# Altium schematic sheet SH3_BI-Gr_Potentiostat_MPUcore_R0.05.00.SchDoc(SH3_BI-Gr_Potentiostat_MPUcore_R0.05.00)
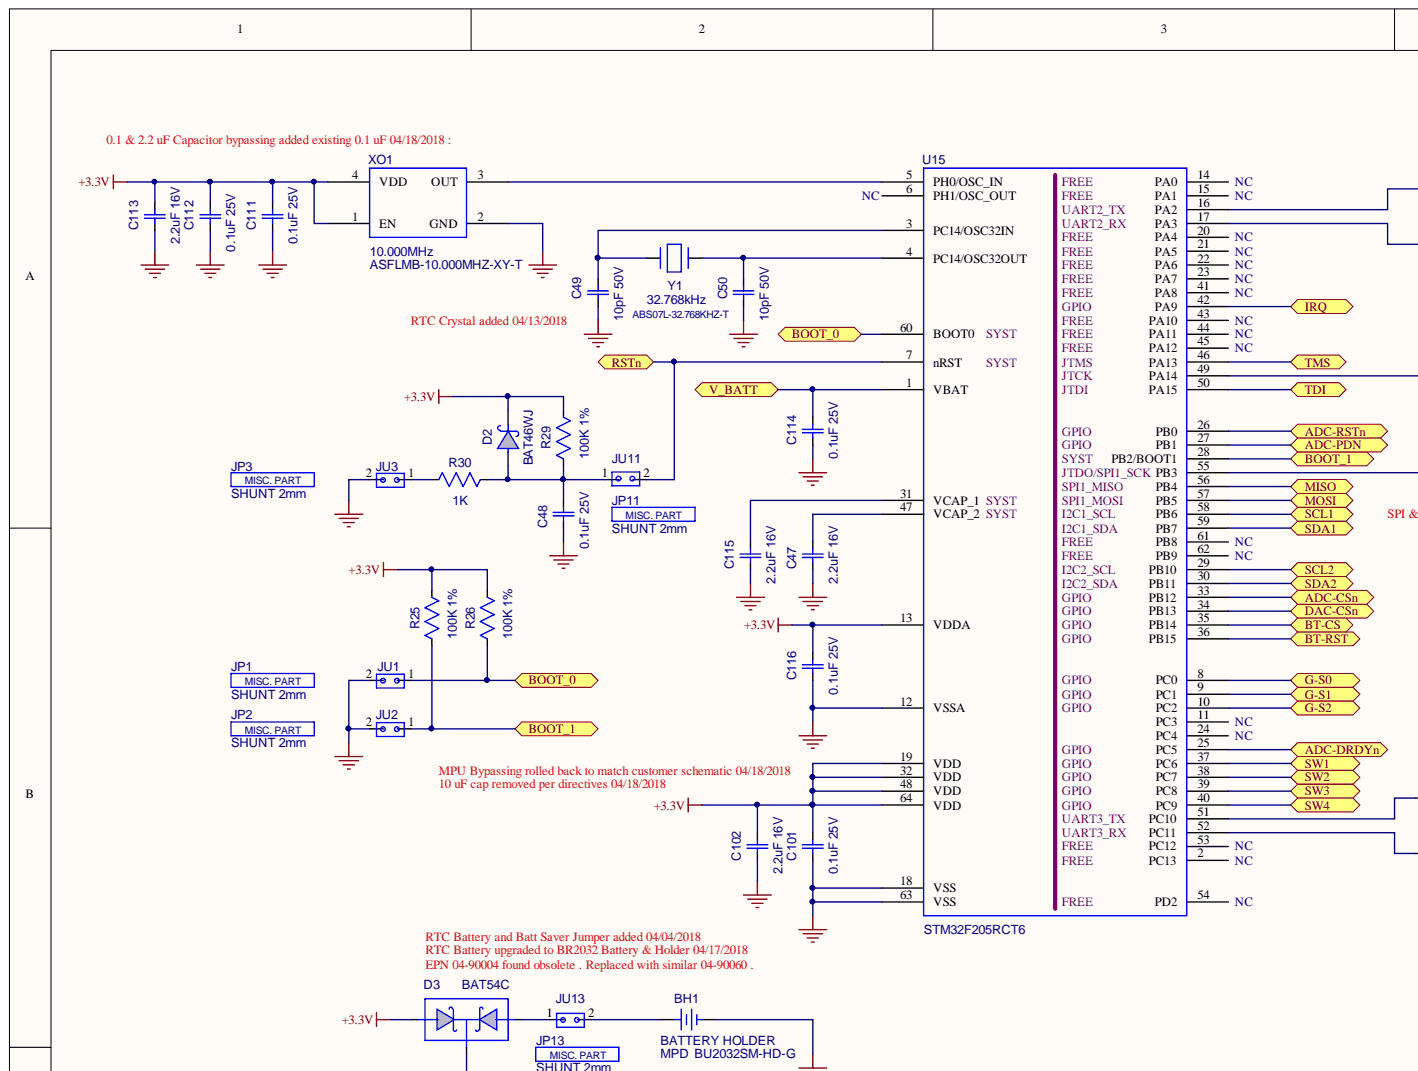
[diagram p0: SH3_BI-Gr_Potentiostat_MPUcore_R0.05.00.SchDoc, top left]
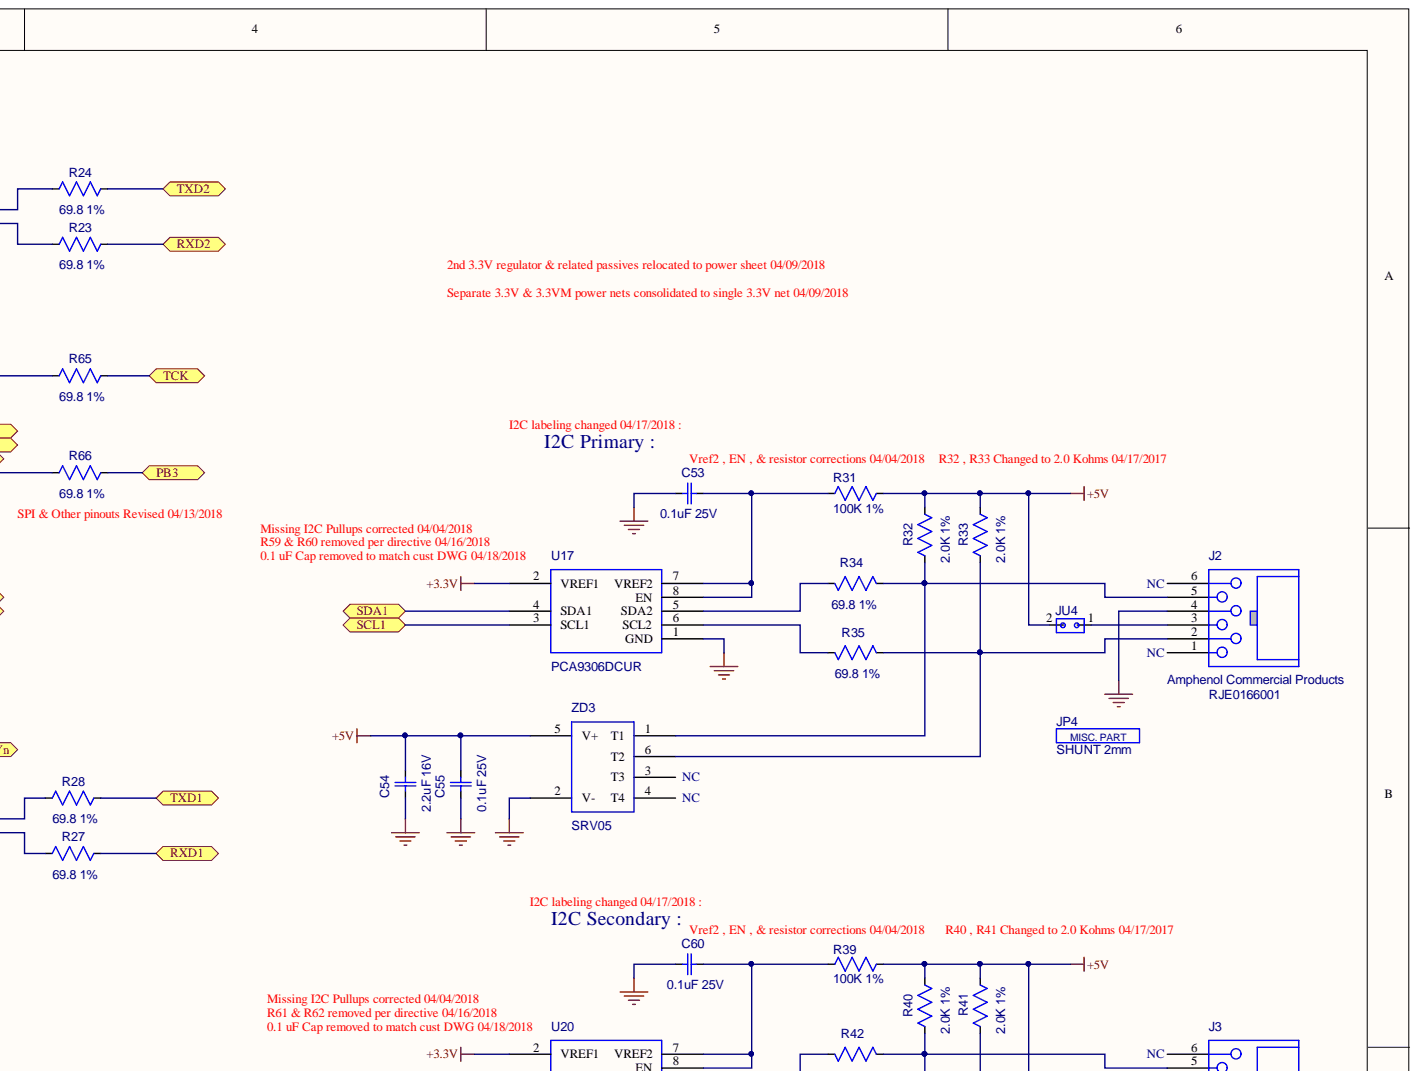
[diagram p1: SH3_BI-Gr_Potentiostat_MPUcore_R0.05.00.SchDoc, top right]
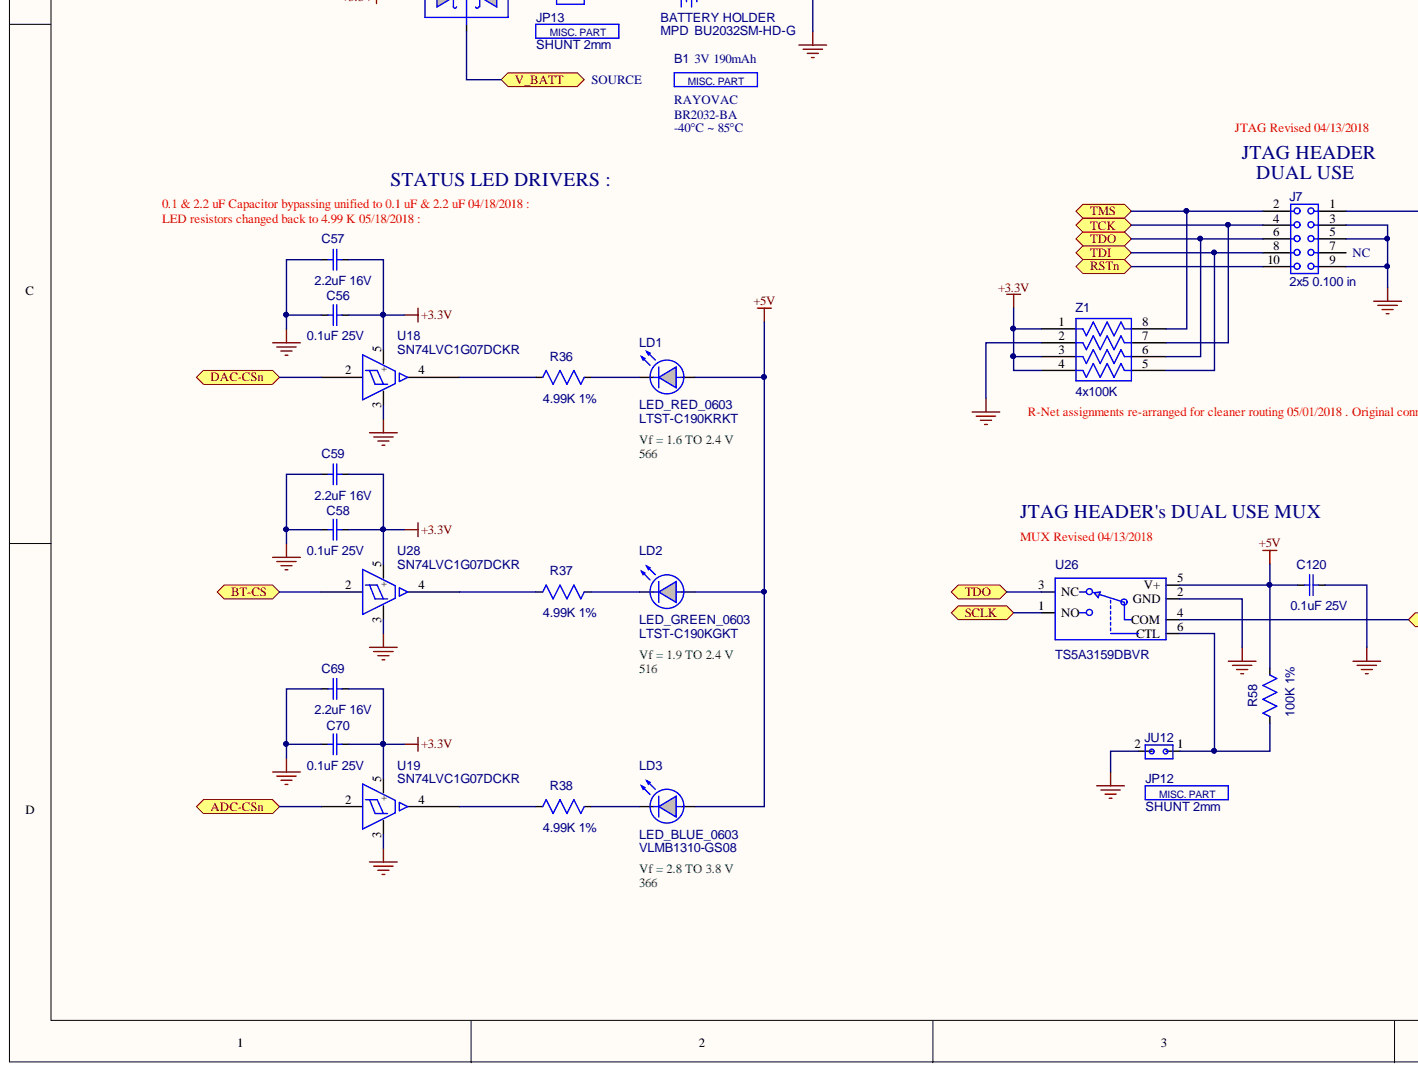
[diagram p2: SH3_BI-Gr_Potentiostat_MPUcore_R0.05.00.SchDoc, bottom left]
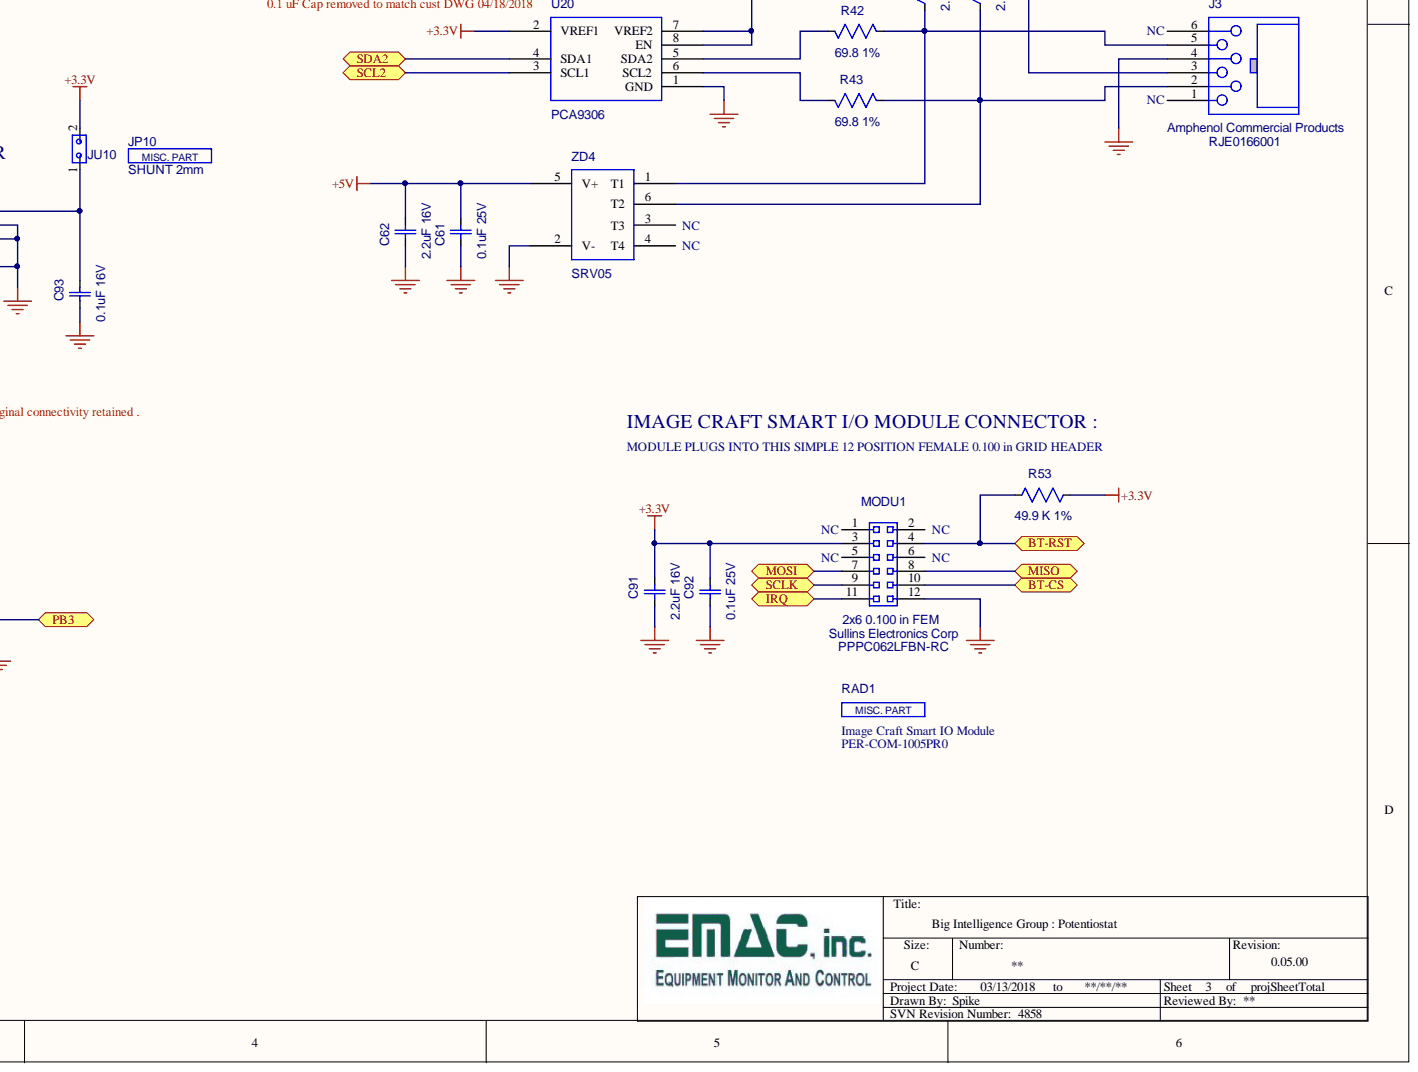
[diagram p3: SH3_BI-Gr_Potentiostat_MPUcore_R0.05.00.SchDoc, bottom right]

## Parts
B1  BR2032  value 3V 190mAh  Temp Range (°C): -40°C ~ 85°C  Notes: 190mAh  Manufacturer PN: BR2032-BA  Manufacturer: RAYOVAC  [PHANTOM_BATT_20MM_COIN_PAT]
BH1  BATTERY HOLDER  Manufacturer PN: BU2032SM-HD-G  Manufacturer: MPD  [BATT_HLDR_BU2032_SM_PAT]
C47  2.2uF 16V  value 2.2uF  Notes: 16V  [CC0603_IPC_L]
C48  0.1uF 25V  Notes: 25V  [CC0603_IPC_L]
C49  10pF 50V  value 10pF  Notes: 50V  [CC0603_IPC_L]
C50  10pF 50V  value 10pF  Notes: 50V  [CC0603_IPC_L]
C53  0.1uF 25V  Notes: 25V  [CC0603_IPC_L]
C54  2.2uF 16V  value 2.2uF  Notes: 16V  [CC0603_IPC_L]
C55  0.1uF 25V  Notes: 25V  [CC0603_IPC_L]
C56  0.1uF 25V  Notes: 25V  [CC0603_IPC_L]
C57  2.2uF 16V  value 2.2uF  Notes: 16V  [CC0603_IPC_L]
C58  0.1uF 25V  Notes: 25V  [CC0603_IPC_L]
C59  2.2uF 16V  value 2.2uF  Notes: 16V  [CC0603_IPC_L]
C60  0.1uF 25V  Notes: 25V  [CC0603_IPC_L]
C61  0.1uF 25V  Notes: 25V  [CC0603_IPC_L]
C62  2.2uF 16V  value 2.2uF  Notes: 16V  [CC0603_IPC_L]
C69  2.2uF 16V  value 2.2uF  Notes: 16V  [CC0603_IPC_L]
C70  0.1uF 25V  Notes: 25V  [CC0603_IPC_L]
C91  2.2uF 16V  value 2.2uF  Notes: 16V  [CC0603_IPC_L]
C92  0.1uF 25V  Notes: 25V  [CC0603_IPC_L]
C93  0.1uF 16V  value 0.1uF  Notes: 16V  [CC0603_IPC_L]
C101  0.1uF 25V  Notes: 25V  [CC0603_IPC_L]
C102  2.2uF 16V  value 2.2uF  Notes: 16V  [CC0603_IPC_L]
C111  0.1uF 25V  Notes: 25V  [CC0603_IPC_L]
C112  0.1uF 25V  Notes: 25V  [CC0603_IPC_L]
C113  2.2uF 16V  value 2.2uF  [CC0603_IPC_L]
C114  0.1uF 25V  Notes: 25V  [CC0603_IPC_L]
C115  2.2uF 16V  value 2.2uF  Notes: 16V  [CC0603_IPC_L]
C116  0.1uF 25V  Notes: 25V  [CC0603_IPC_L]
C120  0.1uF 25V  Notes: 25V  [CC0603_IPC_L]
D2  BAT46WJ  [DIO_BAT46WJ_SOD323]
D3  BAT54C  [SOT23_3_BAT54C]
J2  RJE0166001  Manufacturer: Amphenol Commercial Products  [MOCON_RJE0166001_PAT]
J3  RJE0166001  Manufacturer: Amphenol Commercial Products  [MOCON_RJE0166001_PAT]
J7  Notes: 2x5 0.100 in  [CHDR10_PAT2]  (hidden comment: CHDR10)
JP1  SHUNT 2mm  [SHUNT_2MM_PAT]
JP2  SHUNT 2mm  [SHUNT_2MM_PAT]
JP3  SHUNT 2mm  [SHUNT_2MM_PAT]
JP4  SHUNT 2mm  [SHUNT_2MM_PAT]
JP10  SHUNT 2mm  [SHUNT_2MM_PAT]
JP11  SHUNT 2mm  [SHUNT_2MM_PAT]
JP12  SHUNT 2mm  [SHUNT_2MM_PAT]
JP13  SHUNT 2mm  [SHUNT_2MM_PAT]
JU1  1x2_2mm  [2MMSHDR_02_PAT2]  (value not drawn)
JU2  1x2_2mm  [2MMSHDR_02_PAT2]  (value not drawn)
JU3  1x2_2mm  [2MMSHDR_02_PAT2]  (value not drawn)
JU4  1x2_2mm  [2MMSHDR_02_PAT2]  (value not drawn)
JU10  1x2_2mm  [2MMSHDR_02_PAT2]  (value not drawn)
JU11  1x2_2mm  [2MMSHDR_02_PAT2]  (value not drawn)
JU12  1x2_2mm  [2MMSHDR_02_PAT2]  (value not drawn)
JU13  1x2_2mm  [2MMSHDR_02_PAT2]  (value not drawn)
LD1  LED_RED_0603  Manufacturer PN: LTST-C190KRKT  [LED_0603_RED_PAT]
LD2  LED_GREEN_0603  Manufacturer PN: LTST-C190KGKT  [LED_0603_GRN_PAT]
LD3  LED_BLUE_0603  Manufacturer PN: VLMB1310-GS08  [LED_0603_BLUE_PAT]
MODU1  Notes: 2x6 0.100 in FEM  Manufacturer PN: PPPC062LFBN-RC  Manufacturer: Sullins Electronics Corp  [CHDR12_FEM_PAT]
R23  69.8 1%  [RC0603_IPC_L]
R24  69.8 1%  [RC0603_IPC_L]
R25  100K 1%  [RC0603_IPC_L]
R26  100K 1%  [RC0603_IPC_L]
R27  69.8 1%  [RC0603_IPC_L]
R28  69.8 1%  [RC0603_IPC_L]
R29  100K 1%  [RC0603_IPC_L]
R30  1K  [RC0603_IPC_L]
R31  100K 1%  [RC0603_IPC_L]
R32  2.0K 1%  [RC0603_IPC_L]
R33  2.0K 1%  [RC0603_IPC_L]
R34  69.8 1%  [RC0603_IPC_L]
R35  69.8 1%  [RC0603_IPC_L]
R36  4.99K 1%  [RC0603_IPC_L]
R37  4.99K 1%  [RC0603_IPC_L]
R38  4.99K 1%  [RC0603_IPC_L]
R39  100K 1%  [RC0603_IPC_L]
R40  2.0K 1%  [RC0603_IPC_L]
R41  2.0K 1%  [RC0603_IPC_L]
R42  69.8 1%  [RC0603_IPC_L]
R43  69.8 1%  [RC0603_IPC_L]
R53  49.9 K 1%  [RC0603_IPC_L]
R58  100K 1%  [RC0603_IPC_L]
R65  69.8 1%  [RC0603_IPC_L]
R66  69.8 1%  [RC0603_IPC_L]
RAD1  PER-COM-1005PR0  Notes: Image Craft Smart IO Module  [MOD_SMART_IO_BT]
U15  STM32F205RCT6  [QFP50P1200X1200X160-64N]
U17  9306  Manufacturer PN: PCA9306DCUR  [VSSOP_8_PAT]
U18  SN74LVC1G07DCKR  [SC70-5_PAT]
U19  SN74LVC1G07DCKR  [SC70-5_PAT]
U20  PCA9306  [VSSOP_8_PAT]
U26  TS5A3159DBVR  [RPDSOG_06_SPK]
U28  SN74LVC1G07DCKR  [SC70-5_PAT]
XO1  10.000MHz  Manufacturer PN: ASFLMB-10.000MHZ-XY-T  [XTALOSC_5P0X3P2MM_ASFLMB_PAT]
Y1  32.768kHz  [XTL_ABS07L_PAT]
Z1  4x100K  [RNET_CAT10-0804_X4_PAT]
ZD3  SRV05  [SRV05-4_PAT]
ZD4  SRV05  [SRV05-4_PAT]

## Ports
ADC-CSn, ADC-DRDYn, ADC-PDN, ADC-RSTn, BOOT_0, BOOT_1, BT-CS, BT-RST, DAC-CSn, G-S0, G-S1, G-S2, IRQ, MISO, MOSI, PB3, RSTn, RXD1, RXD2, SCL1, SCL2, SCLK, SDA1, SDA2, SW1, SW2, SW3, SW4, TCK, TDI, TDO, TMS, TXD1, TXD2, V_BATT

## Nets
+3.3V: C56.1 C57.1 C58.1 C59.1 C69.1 C70.1 C91.1 C92.1 C101.1 C102.1 C111.1 C112.1 C113.1 C116.1 D2.1 D3.1 JU10.2 MODU1.3 R25.2 R26.2 R29.2 R53.2 U15.13(VDDA) U15.19(VDD) U15.32(VDD) U15.48(VDD) U15.64(VDD) U17.2(VREF1) U18.5(+) U19.5(+) U20.2(VREF1) U28.5(+) XO1.1(EN) XO1.4(VDD) Z1.1 Z1.3 Z1.4
+5V: C54.1 C55.1 C61.1 C62.1 C120.1 J3.3 JU4.2 LD1.2 LD2.2 LD3.2 R31.2 R32.2 R33.2 R39.2 R40.2 R41.2 R58.2 U26.5(V+) ZD3.5(V+) ZD4.5(V+)
GND: BH1.2 C47.2 C48.2 C49.2 C50.2 C53.2 C54.2 C55.2 C56.2 C57.2 C58.2 C59.2 C60.2 C61.2 C62.2 C69.2 C70.2 C91.2 C92.2 C93.2 C101.2 C102.2 C111.2 C112.2 C113.2 C114.2 C115.2 C116.2 C120.2 J2.4 J3.4 J7.3 J7.5 J7.9 JU1.2 JU2.2 JU3.2 JU12.2 MODU1.12 U15.12(VSSA) U15.18(VSS) U15.63(VSS) U17.1(GND) U18.3 U19.3 U20.1(GND) U26.2(GND) U28.3 XO1.2(GND) Z1.2 ZD3.2(V-) ZD4.2(V-)
ADC-CSn: U15.33(PB12) U19.2 ; port ADC-CSn
ADC-DRDYn: U15.25(PC5) ; port ADC-DRDYn
ADC-PDN: U15.27(PB1) ; port ADC-PDN
ADC-RSTn: U15.26(PB0) ; port ADC-RSTn
BOOT_0: JU1.1 R26.1 U15.60(BOOT0) ; port BOOT_0
BOOT_1: JU2.1 R25.1 U15.28(PB2/BOOT1) ; port BOOT_1
BT-CS: MODU1.10 U15.35(PB14) U28.2 ; port BT-CS
BT-RST: MODU1.4 R53.1 U15.36(PB15) ; port BT-RST
DAC-CSn: U15.34(PB13) U18.2 ; port DAC-CSn
G-S0: U15.8(PC0) ; port G-S0
G-S1: U15.9(PC1) ; port G-S1
G-S2: U15.10(PC2) ; port G-S2
IRQ: MODU1.11 U15.42(PA9) ; port IRQ
MISO: MODU1.8 U15.56(PB4) ; port MISO
MOSI: MODU1.7 U15.57(PB5) ; port MOSI
PB3: R66.2 U26.4(COM) ; port PB3
RSTn: J7.10 JU11.2 U15.7(nRST) ; port RSTn
RXD1: R27.2 ; port RXD1
RXD2: R23.2 ; port RXD2
SCL1: U15.58(PB6) U17.3(SCL1) ; port SCL1
SCL2: U15.29(PB10) U20.3(SCL1) ; port SCL2
SCLK: MODU1.9 U26.1(NO) ; port SCLK
SDA1: U15.59(PB7) U17.4(SDA1) ; port SDA1
SDA2: U15.30(PB11) U20.4(SDA1) ; port SDA2
SW1: U15.37(PC6) ; port SW1
SW2: U15.38(PC7) ; port SW2
SW3: U15.39(PC8) ; port SW3
SW4: U15.40(PC9) ; port SW4
TCK: J7.4 R65.2 Z1.7 ; port TCK
TDI: J7.8 U15.50(PA15) Z1.5 ; port TDI
TDO: J7.6 U26.3(NC) Z1.6 ; port TDO
TMS: J7.2 U15.46(PA13) Z1.8 ; port TMS
TXD1: R28.2 ; port TXD1
TXD2: R24.2 ; port TXD2
V_BATT: C114.1 D3.3 U15.1(VBAT) ; port V_BATT
NetBH1_1: BH1.1 JU13.2
NetC47_1: C47.1 U15.47(VCAP_2)
NetC48_1: C48.1 D2.2 JU11.1 R29.1 R30.2
NetC49_1: C49.1 U15.3(PC14/OSC32IN) Y1.1
NetC50_1: C50.1 U15.4(PC14/OSC32OUT) Y1.3
NetC53_1: C53.1 R31.1 U17.7(VREF2) U17.8(EN)
NetC60_1: C60.1 R39.1 U20.7(VREF2) U20.8(EN)
NetC93_1: C93.1 J7.1 JU10.1
NetC115_1: C115.1 U15.31(VCAP_1)
NetD3_2: D3.2 JU13.1
NetJ2_2: J2.2 R33.1 R35.1 ZD3.6(T2)
NetJ2_3: J2.3 JU4.1
NetJ2_5: J2.5 R32.1 R34.1 ZD3.1(T1)
NetJ3_2: J3.2 R41.1 R43.1 ZD4.6(T2)
NetJ3_5: J3.5 R40.1 R42.1 ZD4.1(T1)
NetJU3_1: JU3.1 R30.1
NetJU12_1: JU12.1 R58.1 U26.6(CTL)
NetLD1_1: LD1.1 R36.1
NetLD2_1: LD2.1 R37.1
NetLD3_1: LD3.1 R38.1
NetR23_1: R23.1 U15.17(PA3)
NetR24_1: R24.1 U15.16(PA2)
NetR27_1: R27.1 U15.52(PC11)
NetR28_1: R28.1 U15.51(PC10)
NetR34_2: R34.2 U17.5(SDA2)
NetR35_2: R35.2 U17.6(SCL2)
NetR36_2: R36.2 U18.4
NetR37_2: R37.2 U28.4
NetR38_2: R38.2 U19.4
NetR42_2: R42.2 U20.5(SDA2)
NetR43_2: R43.2 U20.6(SCL2)
NetR65_1: R65.1 U15.49(PA14)
NetR66_1: R66.1 U15.55(JTDO/SPI1_SCK_PB3)
NetU15_5: U15.5(PH0/OSC_IN) XO1.3(OUT)

Not connected: J2 1 6; J3 1 6; J7 7; MODU1 1 2 5 6; U15 2(PC13) 6(PH1/OSC_OUT) 11(PC3) 14(PA0) 15(PA1) 20(PA4) 21(PA5) 22(PA6) 23(PA7) 24(PC4) 41(PA8) 43(PA10) 44(PA11) 45(PA12) 53(PC12) 54(PD2) 61(PB8) 62(PB9); ZD3 3(T3) 4(T4); ZD4 3(T3) 4(T4)

## Library data of the parts
B1 = 16-90017: BATT^LITHM 3V 190mA 20MM COIN; SVN Revision No: 2917; Status Code: L; Reviewed By: JP; Options: 00; Database Table Name: misc; Type: M; RoHS: YES; Part Number: 16-90017; Package: N/A; MSL: 1; Library Name: MySQL_ALTIUM.DbLib; Height Max: 3.20 mm; Date Updated: 7/12/2016; Datasheet: http://na.industrial.panasonic.com/sites/default/pidsa/files/brseries_datasheets_merged.pdf; Component Kind: Standard; Author: ML
BH1 = 02-90192: NBH^LCN 20mmCR2032 Horiz   SMD; Part Number: {Part Number}; Value: {Value}; Temp Range (C): -40°C ~ 280°C; svn_revision_no: 558; Status Code: L; Options: 00; Notes: {Notes}; ManfacturerPN: {ManfacturerPN}; Information: {Information}; id: 293; Database Table Name: connectors; Type: S; RoHS: YES; Part Number: 02-90192; Library Name: MySQL_ALTIUM.DbLib; Datasheet: http://www.memoryprotectiondevices.com/datasheets/BU2032SM-HD-G-datasheet.pdf; Component Kind: Standard; ComponentHeight: {ComponentHeight}; Verification Date: {Verification Date}; Author: Mike L.
C47 C54 C57 C59 C62 C69 C91 C102 C113 C115 = 09-90070: CCG^  2.2uF  16V 0603  10% X5R; type: S; SVN Revision No.: 5061; Status Code: L; Options: 00; Database Table Name: passives; Part Number: 09-90070; Package: 0603; msl: 1; Library Name: MySQL_ALTIUM.DbLib; Height Max (mm): 0.90 mm; Component Kind: Standard; Author: Mike L.
  C113: Value: 2.2uF; Notes: 16V
C48 C53 C55 C56 C58 C60 C61 C70 C92 C101 C111 C112 C114 C116 C120 = 09-90081: CCG^0.1uF   25V 0603   10% X7R; Manufacturer PN: C0603C104K3RAC7411; Manufacturer: Kemet; Value: .1 uF; type: S; Temp Range (°C): -55°C ~ 125°C; SVN Revision No.: 5061; Status Code: L; Options: 00; Database Table Name: passives; RoHS: YES; Part Number: 09-90081; Package: 0603; msl: 1; Library Name: MySQL_ALTIUM.DbLib; Height Max (mm): 0.90 mm; Component Kind: Standard; Author: Mike L.
C49 C50 = 09-90019: CCG^10pF   50V 0603    5% C0G; Type: S; SVN Revision No.: 5061; Status Code: L; Options: 00; Database Table Name: passives; Part Number: 09-90019; Package: 0603; MSL: 1; Library Name: MySQL_ALTIUM.DbLib; Height Max (mm): 0.87 mm; Component Kind: Standard; Author: Mike L.
C93 = 09-90002: CCG^0.1uF   16V 0603   10% X7R; Manufacturer PN: C0603C104K4RAC7411; Manufacturer: Kemet; Type: S; Temp Range (°C): -55°C ~ 125°C; SVN Revision No.: 5061; Status Code: L; Options: 00; Database Table Name: passives; RoHS: YES; Part Number: 09-90002; Package: 0603; MSL: 1; Library Name: MySQL_ALTIUM.DbLib; Height Max (mm): 0.87 mm; Datasheet: http://www.kemet.com/datasheets&C0603C104K4RAC7411; Component Kind: Standard; Author: Mike L.
D2 = 04-90048: DSB^100V 0.25A BAT46WJ  SOD-32; Manufacturer PN: BAT46WJ,115; Manufacturer: NXP Semiconductors; Type: S; Temp Range (°C): -55°C ~ 150°C; Status Code: P; Options: 00; Notes: Vf=850mV @ 250mA, Vr=100V; Database Table Name: actives; ROHS: YES; Part Number: 04-90048; Package: SOD-323; MSL: 1; Library Name: MySQL_ALTIUM.DbLib; Height Max (mm): 0.80 mm; Datasheet: http://www.nxp.com/documents/data_sheet/BAT46WJ.pdf; Component Kind: Standard; Author: TM
D3 = 04-90005: Diode^Dual Schottkey BAT54C; Part Number: 04-90060; Value: 0.2A 30V; Type: S; Temp Range (°C): -55,  +125; SVN Revision No.: 3604; Status Code: L; Options: 00; Notes: Vbr=30V, If=200mA, Trr=5nS; Database Table Name: actives; ROHS: Yes; Package: SOT23-3; MSL: 1; Manufacturer PN: BAT54C; Library Name: MySQL_ALTIUM.DbLib; Last Updated: 2/14/17; Height Max (mm): 1.20 mm; Datasheet: http://www.diodes.com/datasheets/bat54srs.pdf; Component Kind: Standard; Author: Mike L.
J2 J3 = 02-90498: NMI^JHE RJ-11 6pos6cont Unshld; Temp Range (°C): -55°C ~ 85°C; SVN Revision No.: 4643; Status Code: N; Options: 00; Database Table Name: connectors; Type: M; RoHS: YES; Part Number: 02-90498; MSL: 1; Manufacturer PN: RJE0166001; Library Name: MySQL_ALTIUM.DbLib; Last Updated: 3/20/18; Height Max (mm): 12.88 mm; Datasheet: https://www.amphenolcanada.com/ProductSearch/pdf/RJE01_BRO.pdf; Component Kind: Standard; Author: JP
J7 = 20-0310: NHD^MPE 2X5 0.1" GOLD PRE; Manufacturer: EMAC inc.; Temp Range (°C): {Temp Range}; SVN Revision No.: 1967; Status Code: L; Options: 00; Information: {Information}; Database Table Name: connectors; Type: M; RoHS: YES; Part Number: 20-0310; MSL: N/A; Library Name: MySQL_ALTIUM.DbLib; Height Max (mm): 8.50 mm; Component Kind: Standard; Author: ML
JP1-JP4 JP10-JP13 = 02-0701: SHUNT^HDR MICRO 2MM; Manufacturer PN: 86730-101LF; Manufacturer: FCI; Temp Range (°C): {Temp Range}; SVN Revision No.: 2917; Status Code: L; Options: 00; Notes: {Notes}; Information: {Information}; Database Table Name: connectors; Type: M; RoHS: YES; Part Number: 02-0701; MSL: 1; Library Name: MySQL_ALTIUM.DbLib; Height Max (mm): 3.50 mm; Component Kind: Standard; Author: Mike L.
JU1-JU4 JU10-JU13 = 20-1502: NHD^MPM SR 1X2 2mm TH PRE; Manufacturer: EMAC inc.; Temp Range (°C): -45C ~ 105C; SVN Revision No.: 4614; Status Code: N; Options: 00; Database Table Name: connectors; Type: M; RoHS: YES; Part Number: 20-1502; MSL: 1; Library Name: MySQL_ALTIUM.DbLib; Height Max (mm): 5.80 mm; Component Kind: Standard; Author: TM
LD1 = 07-90034: LED^RED CLEAR SMT 0603; Manufacturer: Lite-On Inc; Value: Red; Type: S; Temp Range (°C): -55°C ~ 85°C; SVN Revision No.: 1348; Status Code: A; Options: 00; Notes: Vf=2V, Imax=20mA; Database Table Name: actives; ROHS: YES; Part Number: 07-90034; Package: 0603; MSL: 3; Library Name: MySQL_ALTIUM.DbLib; Height Max (mm): 0.90 mm; Datasheet: http://optoelectronics.liteon.com/en-us/api/DwonloadFileHandler.ashx?txtSpecNo=DS-22-99-0151&txtPartNo=LTST-C190KRKT; Component Kind: Standard; Author: JP
LD2 = 07-90033: LED^GREEN CLEAR SMT 0603; Manufacturer: Lite-On Inc; Value: Green; Type: S; Temp Range (°C): -55°C ~ 85°C; SVN Revision No.: 1348; Status Code: A; Options: 00; Notes: Vf=2V, Imax=20mA; Database Table Name: actives; ROHS: YES; Part Number: 07-90033; Package: 0603; MSL: 3; Library Name: MySQL_ALTIUM.DbLib; Height Max (mm): 0.90 mm; Datasheet: http://optoelectronics.liteon.com/en-us/api/DwonloadFileHandler.ashx?txtSpecNo=DS-22-99-0151&txtPartNo=LTST-C190KRKT; Component Kind: Standard; Author: JP
LD3 = 07-90064: LED_BLUE 0603 SMT; Manufacturer: Vishay Semiconductor Opto Division; Value: BLUE; Type: S; Temp Range (°C): -35°C ~ 85°C; SVN Revision No.: VersionControl; Status Code: N; Options: 00; Notes: Vf=3.3V, Iv=103mcd, If=20mA; Database Table Name: actives; ROHS: YES; Part Number: 07-90064; Package: 0603; MSL: 3; Library Name: MySQL_ALTIUM.DbLib; Height Max (mm): 1.00 mm; Datasheet: http://www.vishay.com/docs/82437/vlmo1300.pdf; Component Kind: Standard; Author: TM
MODU1 = 02-90499: NHD^FSE 2x6 POS 2.54MM FEM; Temp Range (°C): -40°C ~ 105°C; SVN Revision No.: VersionControl; Status Code: N; Options: 00; Database Table Name: connectors; Type: M; RoHS: YES; Part Number: 02-90499; MSL: 1; Library Name: MySQL_ALTIUM.DbLib; Last Updated: 4/12/18; Height Max (mm): 8.50 mm; Component Kind: Standard; Author: JP
R23 R24 R27 R28 R34 R35 R42 R43 R65 R66 = 10-90453: RCP^  69.8 ohm 1% 0.100W  0603; Manufacturer PN: RC0603FR-0769R8L; Manufacturer: Yageo; Temp Range (°C): -55°C ~ 155°C; SVN Revision No.: 3043; Status Code: N; Options: 00; Notes: Moisture Resistant Thick Film; Database Table Name: resistors; Value: 69.8 1%; Type: S; RoHS: YES; Part Number: 10-90453; Package: 0603; MSL: 1; Library Name: MySQL_ALTIUM.DbLib; Last Updated: 3/20/18; Height Max (mm): 0.55 mm; Datasheet: http://www.yageo.com/documents/recent/PYu-RC_Group_51_RoHS_L_9.pdf; Component Kind: Standard; Author: JP
R25 R26 R29 R31 R39 R58 = 10-90076: RCP^ 100K ohm 1% 0.100W 0603; Manufacturer PN: RC0603FR-07100KL; SVN Revision No.: 3043; Status Code: L; Options: 00; Database Table Name: resistors; Value: 100K 1%; Type: S; Part Number: 10-90076; Package: 0603; MSL: 1; Library Name: MySQL_ALTIUM.DbLib; Height Max (mm): 0.55 mm; Component Kind: Standard; Author: Mike L.
R30 = 10-90033: RCP_1K ohm 5% 0.100W  0603; Manufacturer PN: CRCW06031K00JNEA; Manufacturer: Vishay Dale; Temp Range (°C): -55°C ~ 155°C; SVN Revision No.: 3043; Status Code: L; Options: 00; Database Table Name: resistors; Value: 1K; Type: S; RoHS: YES; Part Number: 10-90033; Package: 0603; MSL: 1; Library Name: MySQL_ALTIUM.DbLib; Height Max (mm): 0.55 mm; Datasheet: http://www.vishay.com/docs/20035/dcrcwe3.pdf; Component Kind: Standard; Author: Mike L.
R32 R33 R40 R41 = 10-90408: RCP^   2.0Kohm 1% 0.100W  0603; Manufacturer PN: RC0603FR-072KL; Manufacturer: Yageo; Temp Range (°C): -55°C ~ 155°C; SVN Revision No.: 3043; Status Code: P; Options: 00; Database Table Name: resistors; Value: 2.0K 1%; Type: S; RoHS: YES; Part Number: 10-90408; Package: 0603; MSL: 1; Library Name: MySQL_ALTIUM.DbLib; Last Updated: 9/16/16; Height Max (mm): 0.55 mm; Datasheet: http://www.yageo.com/documents/recent/PYu-RC_Group_51_RoHS_L_04.pdf; Component Kind: Standard; Author: ML
R36-R38 = 10-90075: RCP^ 4.99K ohm 1% 0.062W  0603; SVN Revision No.: 3043; Status Code: L; Options: 00; Database Table Name: resistors; Value: 4.99K 1%; Type: S; Part Number: 10-90075; Package: 0603; MSL: 1; Library Name: MySQL_ALTIUM.DbLib; Height Max (mm): 0.55 mm; Component Kind: Standard; Author: Mike L.
R53 = 10-90222: RCP^ 49.9 Kohm 1% 0.100W  0603; Manufacturer PN: 302-49.9K-RC; Manufacturer: Xicon; Temp Range (°C): -55°C ~ 125°C; SVN Revision No.: 3043; Status Code: L; Options: 00; Database Table Name: resistors; Value: 49.9K 1%; Type: S; RoHS: YES; Part Number: 10-90222; Package: 0603; MSL: 1; Library Name: MySQL_ALTIUM.DbLib; Height Max (mm): 0.55 mm; Datasheet: http://www.mouser.com/ds/2/351/RoyalElectronic_XC-600037-469831.pdf; Component Kind: Standard; Author: Mike L.
RAD1 = 47: BlueTth Module 3.3V IntANT SDIO; Options: 00; Database Table Name: phantom; Part Number: PER-COM-1005PR0; Library Name: MySQL_ALTIUM.DbLib; Last Updated: 4/11/18; id: 47; Component Kind: Standard; Author: {Author}
U15 = 06-90628: UCP^32 STM32F205RCT6   LQFP-64; Manufacturer: STMicroelectronics; Temp Range (°C): -40°C ~ 85°C; SVN Revision No.: 4637; Status Code: N; Options: 00; Notes: Vs=1.8V~3.6V,32bit, 120MHz; Database Table Name: ics; Type: S; RoHS: YES; Part Number: 06-90628; Package: LQFP-64; MSL: 3; Manufacturer PN: STM32F205RCT6; Library Name: MySQL_ALTIUM.DbLib; Height Max (mm): 1.60 mm; Datasheet: https://media.digikey.com/pdf/Data%20Sheets/ST%20Microelectronics%20PDFS/STM32F20(5,7)xx.pdf; Component Kind: Standard; Author: TM
U17 = 06-90411: UIF^LT BiDi BiLVL I2C VSS0P-08; Manufacturer: Texas Instruments; Temp Range (°C): -40°C ~ 85°C; SVN Revision No.: 1095; Status Code: N; Options: 00; Notes: VCCA=1.2 V ~ 3.3 V,VCCB=1.8 V ~ 5.5 V; Database Table Name: ics; Type: S; RoHS: YES; Part Number: 06-90411; Package: VSS0P-08; MSL: 1; Library Name: MySQL_ALTIUM.DbLib; Last Updated: 3/28/17; Height Max (mm): 0.90 mm; Datasheet: http://www.ti.com/lit/ds/symlink/pca9306.pdf; Component Kind: Standard; Author: ML
U18 U19 U28 = 06-90338: UDL^GS Schmitt OPNDRN   SC70-5; Manufacturer: TI; Temp Range (°C): -40°C ~ 125°C; SVN Revision No.: 2529; Status Code: L; Options: 00; Notes: Vs=1.65V ~ 5.5V; Database Table Name: ics; Type: S; RoHS: YES; Part Number: 06-90338; Package: SC70-5; MSL: 1; Manufacturer PN: SN74LVC1G07DCKR; Library Name: MySQL_ALTIUM.DbLib; Last Updated: 2/20/17; Height Max (mm): 1.10 mm; Datasheet: http://www.ti.com/lit/ds/symlink/sn74lvc1g07.pdf; Component Kind: Standard; Author: Mike L.
U20 = 06-90411: UIF^LT BiDi BiLVL I2C VSS0P-08; Manufacturer PN: PCA9306DCUR; Manufacturer: Texas Instruments; Temp Range (°C): -40°C ~ 85°C; SVN Revision No.: 1095; Status Code: N; Options: 00; Notes: VCCA=1.2 V ~ 3.3 V,VCCB=1.8 V ~ 5.5 V; Database Table Name: ics; Type: S; RoHS: YES; Part Number: 06-90411; Package: VSS0P-08; MSL: 1; Library Name: MySQL_ALTIUM.DbLib; Last Updated: 3/28/17; Height Max (mm): 0.90 mm; Datasheet: http://www.ti.com/lit/ds/symlink/pca9306.pdf; Component Kind: Standard; Author: ML
U26 = 06-90106: UAN^AX SPDT 1 Ohm    SOT23-6; Manufacturer: Texas Instruments; Temp Range (°C): -40°C ~ 85°C; SVN Revision No.: 558; Status Code: L; Options: 00; Notes: Vs=1.65 V ~ 5.5 V, Is=100nA; Database Table Name: ics; Type: S; RoHS: YES; Part Number: 06-90106; Package: SOT-23-6; MSL: 1; Manufacturer PN: TS5A3159DBVR; Library Name: MySQL_ALTIUM.DbLib; Height Max (mm): 1.45 mm; Datasheet: http://www.ti.com/lit/ds/symlink/ts5a3159.pdf; Component Kind: Standard; Author: Mike L.
XO1 = 14-90063: XM3^10.000MHz 10PPM 3.2x5.0 MM; Manufacturer: Abracon LLC; Temp Range (°C): -40°C ~ 105°C; SVN Revision No.: VersionControl; Status Code: N; Options: 00; Notes: Vs=1.8V~3.3V, MEMS, ±10ppm; Database Table Name: actives; Value: 10.000MHz; Type: S; ROHS: YES; Part Number: 14-90063; Package: 4-SMD; MSL: 1; Library Name: MySQL_ALTIUM.DbLib; Height Max (mm): 0.90 mm; Datasheet: http://www.abracon.com/Oscillators/ASFLMB.pdf; Component Kind: Standard; Author: TM
Y1 = 14-90047: XTF^32.768K 12.5PF ABS07L; Manufacturer PN: ABS07L-32.768KHZ-T; Manufacturer: Abracon LLC; Temp Range (°C): -40°C ~ 85°C; SVN Revision No.: 558; Status Code: A; Options: 00; Notes: 55 kOhm, 12.5pF, ±20ppm; Database Table Name: actives; Value: 32.768kHz; Type: S; ROHS: YES; Part Number: 14-90047; Package: 2-SMD; MSL: 1; Library Name: MySQL_ALTIUM.DbLib; Last Updated: 9/28/16; Height Max (mm): 0.38 mm; Datasheet: http://www.abracon.com/Resonators/ABS07L.pdf; Component Kind: Standard; Author: JP
Z1 = 10-90234: RNI^ 4x100K ohm 5% 0.031W 0804; Manufacturer PN: CAT10-104J4LF; Manufacturer: Bourns Inc.; Temp Range (°C): -55°C ~ 125°C; SVN Revision No.: 2500; Status Code: L; Options: 00; Database Table Name: resistors; Value: 4x100K; Type: S; RoHS: YES; Part Number: 10-90234; Package: 0804; MSL: 1; Library Name: MySQL_ALTIUM.DbLib; Height Max (mm): 0.55 mm; Datasheet: http://www.bourns.com/docs/Product-Datasheets/CAT10.pdf; Component Kind: Standard; Author: Mike L.
ZD3 ZD4 = 15-90012: ECA^4x15KV 40A 3pF SMD SOT23-6; Manufacturer PN: SRV05-4.TCT; Manufacturer: Semtech; Temp Range (°C): -55°C ~ 125°C; SVN Revision No.: 665; Status Code: L; Options: 00; Notes: Vbr=6V, P=300W,; Database Table Name: misc; Type: S; RoHS: YES; Part Number: 15-90012; Package: SOT23-6; MSL: 1; Library Name: MySQL_ALTIUM.DbLib; Last Updated: 9/15/16; Height Max (mm): 1.45 mm; Datasheet: http://www.semtech.com/images/datasheet/srv05-4.pdf; Component Kind: Standard; Author: Mike L.
